# Altium schematic sheet Sheet1.SchDoc
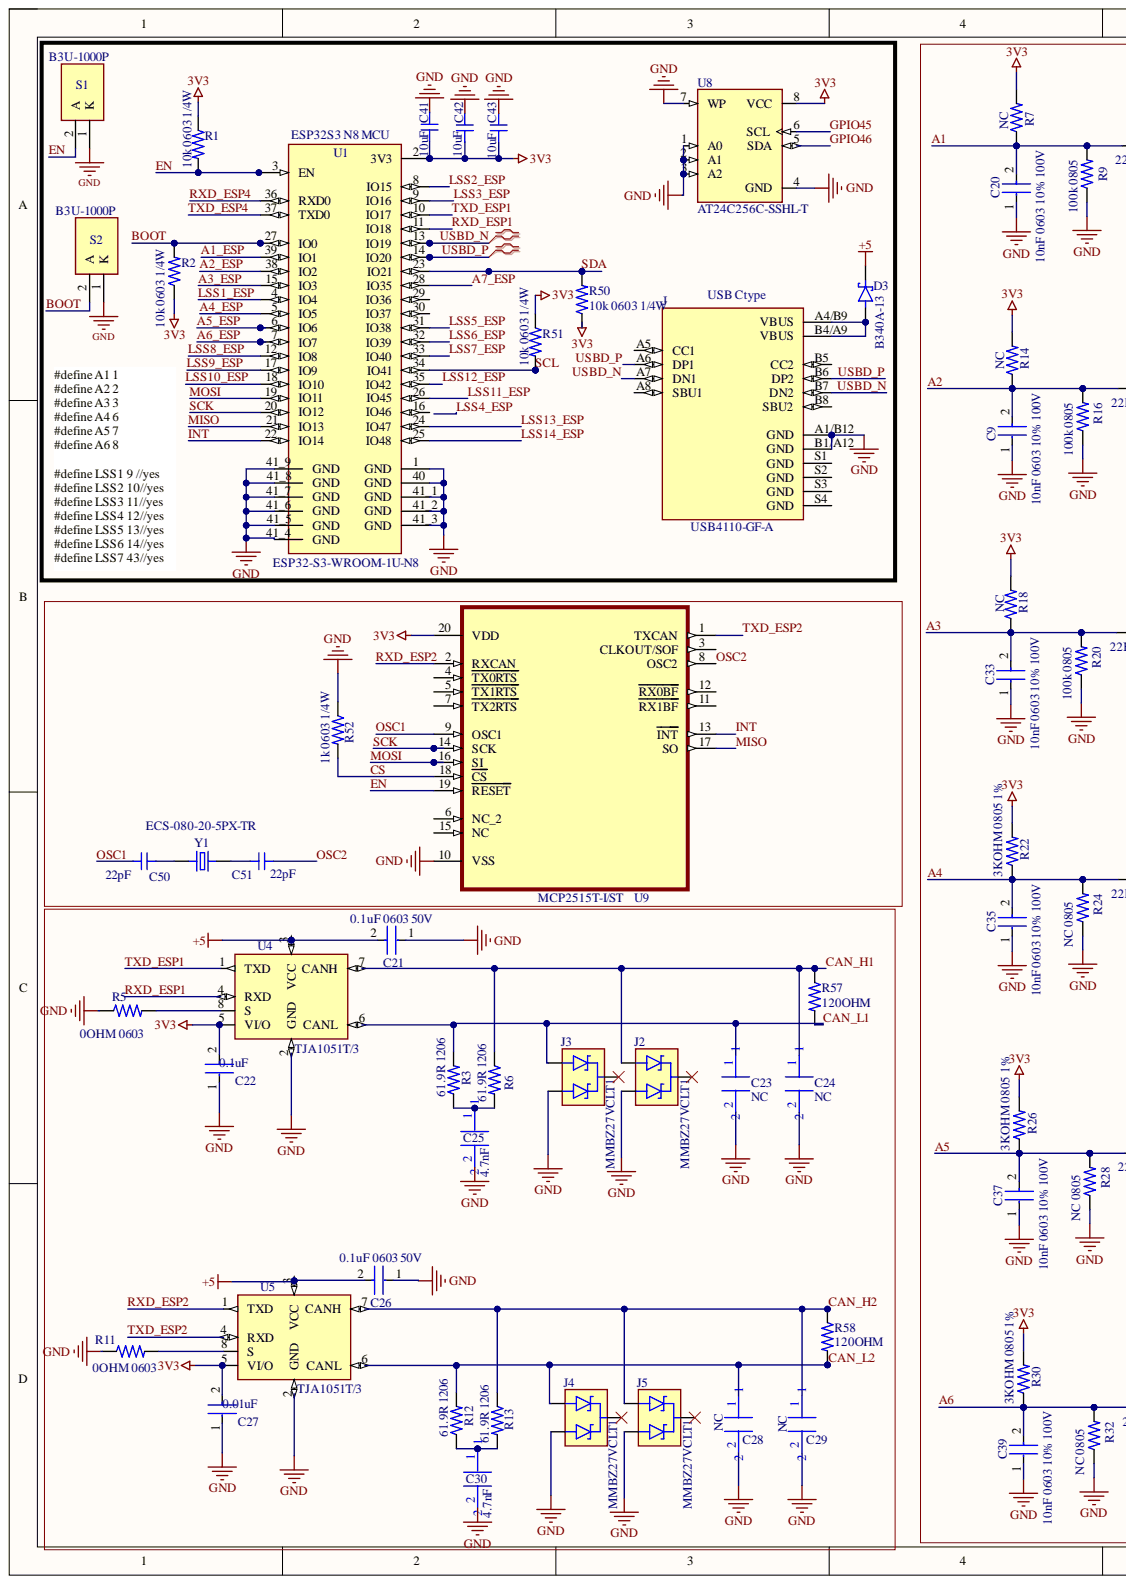
[diagram p0: Sheet1.SchDoc, left]
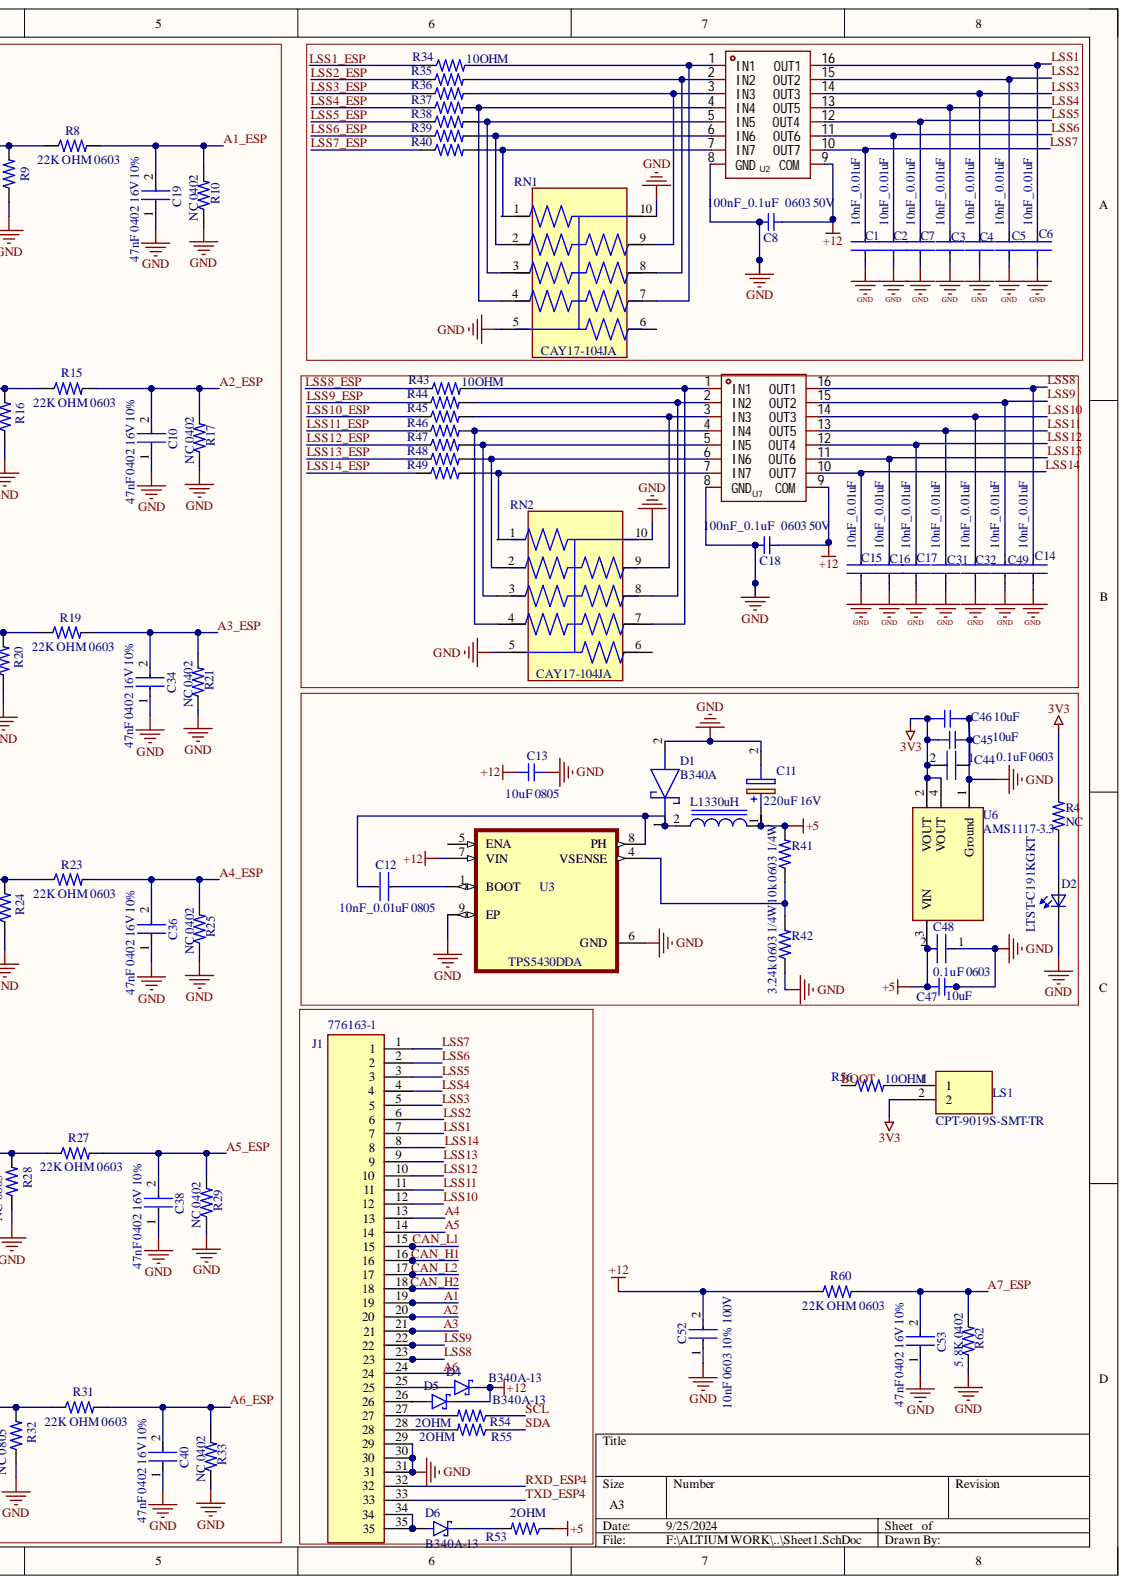
[diagram p1: Sheet1.SchDoc, right]

## Parts
C1  10nF_0.01uF  [0805]
C2  10nF_0.01uF  [0805]
C3  10nF_0.01uF  [0805]
C4  10nF_0.01uF  [0805]
C5  10nF_0.01uF  [0805]
C6  10nF_0.01uF  [0805]
C7  10nF_0.01uF  [0805]
C8  100nF_0.1uF   0603 50V  [Kemet_C0603_0]
C9  10nF 0603 10% 100V  [Kemet_C0603_0]
C10  47nF 0402 16V 10%  [CAPC0402X22N]
C11  220uF 16V  [CAPAE405X580N]
C12  10nF_0.01uF 0805  [0805]
C13  10uF 0805  [0805]
C14  10nF_0.01uF  [0805]
C15  10nF_0.01uF  [0805]
C16  10nF_0.01uF  [0805]
C17  10nF_0.01uF  [0805]
C18  100nF_0.1uF   0603 50V  [Kemet_C0603_0]
C19  47nF 0402 16V 10%  [CAPC0402X22N]
C20  10nF 0603 10% 100V  [Kemet_C0603_0]
C21  0.1uF 0603 50V  [Kemet_C0603_0]
C22  0.1uF  [Kemet_C0603_0]
C23  NC  [0805]
C24  NC  [0805]
C25  4.7nF  [0805]
C26  0.1uF 0603 50V  [Kemet_C0603_0]
C27  0.01uF  [Kemet_C0603_0]
C28  NC  [0805]
C29  NC  [0805]
C30  4.7nF  [0805]
C31  10nF_0.01uF  [0805]
C32  10nF_0.01uF  [0805]
C33  10nF 0603 10% 100V  [Kemet_C0603_0]
C34  47nF 0402 16V 10%  [CAPC0402X22N]
C35  10nF 0603 10% 100V  [Kemet_C0603_0]
C36  47nF 0402 16V 10%  [CAPC0402X22N]
C37  10nF 0603 10% 100V  [Kemet_C0603_0]
C38  47nF 0402 16V 10%  [CAPC0402X22N]
C39  10nF 0603 10% 100V  [Kemet_C0603_0]
C40  47nF 0402 16V 10%  [CAPC0402X22N]
C41  10uF  [0805]
C42  10uF  [0805]
C43  10uF  [0805]
C44  0.1uF 0603  [Kemet_C0603_0]
C45  10uF  [0805]
C46  10uF  [0805]
C47  10uF  [0805]
C48  0.1uF 0603  [Kemet_C0603_0]
C49  10nF_0.01uF  [0805]
C50  22pF  [Kemet_C0603_0]
C51  22pF  [Kemet_C0603_0]
C52  10nF 0603 10% 100V  [Kemet_C0603_0]
C53  47nF 0402 16V 10%  [CAPC0402X22N]
D1  B340A  [SOD3716X145N]
D2  LTST-C191KGKT  [0603]
D3  B340A-13  [DIOM5226X240N]
D4  B340A-13  [DIOM5226X240N]
D5  B340A-13  [DIOM5226X240N]
D6  B340A-13  [DIOM5226X240N]
J  USB4110-GF-A  [GCT_USB4105-GF-A]
J1  776163-1  [TE_776163-1]
J2  MMBZ27VCLT1  [SOT23-3]
J3  MMBZ27VCLT1  [SOT23-3]
J4  MMBZ27VCLT1  [SOT23-3]
J5  MMBZ27VCLT1  [SOT23-3]
L1  330uH  [INDPM5350X450N]
LS1  CPT-9019S-SMT-TR  [CPT9019SSMTTR]
R1  10k 0603 1/4W  [0603_R]
R2  10k 0603 1/4W  [0603_R]
R3  61.9R 1206  [R1206]
R4  NC  Package: 0603  [0603_R]
R5  0OHM 0603  Package: 0603  [0603_R]
R6  61.9R 1206  [R1206]
R7  NC  [R0805]
R8  22K OHM 0603  Package: 0603  [0603_R]
R9  100k 0805  [R0805]
R10  NC 0402  [R0402]
R11  0OHM 0603  Package: 0603  [0603_R]
R12  61.9R 1206  [R1206]
R13  61.9R 1206  [R1206]
R14  NC  [R0805]
R15  22K OHM 0603  Package: 0603  [0603_R]
R16  100k 0805  [R0805]
R17  NC 0402  [R0402]
R18  NC  [R0805]
R19  22K OHM 0603  Package: 0603  [0603_R]
R20  100k 0805  [R0805]
R21  NC 0402  [R0402]
R22  3KOHM 0805 1%  [R0805]
R23  22K OHM 0603  Package: 0603  [0603_R]
R24  NC 0805  [R0805]
R25  NC 0402  [R0402]
R26  3KOHM 0805 1%  [R0805]
R27  22K OHM 0603  Package: 0603  [0603_R]
R28  NC 0805  [R0805]
R29  NC 0402  [R0402]
R30  3KOHM 0805 1%  [R0805]
R31  22K OHM 0603  Package: 0603  [0603_R]
R32  NC 0805  [R0805]
R33  NC 0402  [R0402]
R34  10OHM  [R0402]
R35  10OHM  [R0402]
R36  10OHM  [R0402]
R37  10OHM  [R0402]  (value not drawn)
R38  10OHM  [R0402]  (value not drawn)
R39  10OHM  [R0402]  (value not drawn)
R40  10OHM  [R0402]  (value not drawn)
R41  10k 0603 1/4W  [0603_R]
R42  3.24k 0603 1/4W  [0603_R]
R43  10OHM  [R0402]
R44  10OHM  [R0402]
R45  10OHM  [R0402]
R46  10OHM  [R0402]  (value not drawn)
R47  10OHM  [R0402]  (value not drawn)
R48  10OHM  [R0402]  (value not drawn)
R49  10OHM  [R0402]  (value not drawn)
R50  10k 0603 1/4W  [0603_R]
R51  10k 0603 1/4W  [0603_R]
R52  1k 0603 1/4W  [0603_R]
R53  2OHM  [R1206]
R54  2OHM  [R1206]
R55  2OHM  [R1206]
R56  10OHM  [R0402]
R57  120OHM  [R1206]
R58  120OHM  [R1206]
R60  22K OHM 0603  Package: 0603  [0603_R]
R62  5.8K 0402  [R0402]
RN1  CAY17-104JA  [RESCAXS64P320X160X60-10N]
RN2  CAY17-104JA  [RESCAXS64P320X160X60-10N]
S1  B3U-1000P  [B3U-1000P]
S2  B3U-1000P  [B3U-1000P]
U1  ESP32-S3-WROOM-1U-N8  [XCVR_ESP32-S3-WROOM-1U]
U2  TPL7407LAQPWRQ1  [TSSOP-16_L5.0-W4.4-P0.65-LS6.4-BL]  (value not drawn)
U3  TPS5430DDA  [VREG_LM5017MR/NOPB]
U4  TJA1051T/3  [SOT96-1]
U5  TJA1051T/3  [SOT96-1]
U6  AMS1117-3.3  [Advanced_Monolithic_Systems_AMS1117_IPC_A]
U7  TPL7407LAQPWRQ1  [TSSOP-16_L5.0-W4.4-P0.65-LS6.4-BL]  (value not drawn)
U8  AT24C256C-SSHL-T  [SOIC127P600X175-8N - duplicate3]
U9  MCP2515T-I/ST  [SOP65P640X120-20N]
Y1  ECS-080-20-5PX-TR  [XTAL_ECS-080-20-5PX-TR]

## Nets
3V3: C22.2 C27.2 C41.1 C42.1 C43.1 C44.2 C45.2 C46.2 LS1.2 R1.1 R2.1 R4.2 R7.2 R14.2 R18.2 R22.2 R26.2 R30.2 R50.1 R51.1 U1.2(3V3) U4.5(VI/O) U5.5(VI/O) U6.2(VOUT) U6.4(VOUT) U8.8(VCC) U9.20(VDD)
+5: C11.1 C21.2 C26.2 C47.2 C48.2 D3.C L1.1 R41.2 R53.1 U4.3(VCC) U5.3(VCC) U6.3(VIN)
+12: C8.2 C13.2 C18.2 C52.2 D4.C D5.C R60.1 U2.9(COM) U3.7(VIN) U7.9(COM)
GND: C1.1 C2.1 C3.1 C4.1 C5.1 C6.1 C7.1 C8.1 C9.1 C10.1 C11.2 C13.1 C14.1 C15.1 C16.1 C17.1 C18.1 C19.1 C20.1 C21.1 C22.1 C23.2 C24.2 C25.2 C26.1 C27.1 C28.2 C29.2 C30.2 C31.1 C32.1 C33.1 C34.1 C35.1 C36.1 C37.1 C38.1 C39.1 C40.1 C41.2 C42.2 C43.2 C44.1 C45.1 C46.1 C47.1 C48.1 C49.1 C52.1 C53.1 D1.2 D2.1 J.A1/B12(GND) J.B1/A12(GND) J1.29 J1.30 J1.31 J2.2 J3.2 J4.2 J5.2 R5.1 R9.1 R10.1 R11.1 R16.1 R17.1 R20.1 R21.1 R24.1 R25.1 R28.1 R29.1 R32.1 R33.1 R42.1 R52.1 R62.1 RN1.5 RN1.10 RN2.5 RN2.10 S1.1 S2.1 U1.1(GND) U1.40(GND) U1.41_1(GND) U1.41_2(GND) U1.41_3(GND) U1.41_4(GND) U1.41_5(GND) U1.41_6(GND) U1.41_7(GND) U1.41_8(GND) U1.41_9(GND) U2.8(GND) U3.6(GND) U3.9(EP) U4.2(GND) U5.2(GND) U6.1(Ground) U7.8(GND) U8.1(A0) U8.2(A1) U8.3(A2) U8.4(GND) U8.7(WP) U9.10(VSS)
A1: C20.2 J1.19 R7.1 R8.1 R9.2
A1_ESP: C19.2 R8.2 R10.2 U1.39(IO1)
A2: C9.2 J1.20 R14.1 R15.1 R16.2
A2_ESP: C10.2 R15.2 R17.2 U1.38(IO2)
A3: C33.2 J1.21 R18.1 R19.1 R20.2
A3_ESP: C34.2 R19.2 R21.2 U1.15(IO3)
A4: C35.2 J1.13 R22.1 R23.1 R24.2
A4_ESP: C36.2 R23.2 R25.2 U1.5(IO5)
A5: C37.2 J1.14 R26.1 R27.1 R28.2
A5_ESP: C38.2 R27.2 R29.2 U1.6(IO6)
A6: C39.2 J1.24 R30.1 R31.1 R32.2
A6_ESP: C40.2 R31.2 R33.2 U1.7(IO7)
A7_ESP: C53.2 R60.2 R62.2 U1.28(IO35)
BOOT: R2.2 R56.1 S2.2 U1.27(IO0)
CAN_H1: C24.1 J1.16 J2.1 R6.1 R57.1 U4.7(CANH)
CAN_H2: C29.1 J1.18 J5.1 R13.1 R58.1 U5.7(CANH)
CAN_L1: C23.1 J1.15 J3.1 R3.2 R57.2 U4.6(CANL)
CAN_L2: C28.1 J1.17 J4.1 R12.2 R58.2 U5.6(CANL)
CS: R52.2 U9.18(CS)
EN: R1.2 S1.2 U1.3(EN) U9.19(RESET)
GPIO45: U8.6(SCL)
GPIO46: U8.5(SDA)
INT: U1.22(IO14) U9.13(INT)
LSS1: C6.2 J1.7 U2.16(OUT1)
LSS1_ESP: R34.1 U1.4(IO4)
LSS2: C5.2 J1.6 U2.15(OUT2)
LSS2_ESP: R35.1 U1.8(IO15)
LSS3: C4.2 J1.5 U2.14(OUT3)
LSS3_ESP: R36.1 U1.9(IO16)
LSS4: C3.2 J1.4 U2.13(OUT5)
LSS4_ESP: R37.1
LSS5: C7.2 J1.3 U2.12(OUT4)
LSS5_ESP: R38.1 U1.31(IO38)
LSS6: C2.2 J1.2 U2.11(OUT6)
LSS6_ESP: R39.1 U1.32(IO39)
LSS7: C1.2 J1.1 U2.10(OUT7)
LSS7_ESP: R40.1 U1.33(IO40)
LSS8: C14.2 J1.23 U7.16(OUT1)
LSS8_ESP: R43.1 U1.12(IO8)
LSS9: C49.2 J1.22 U7.15(OUT2)
LSS9_ESP: R44.1 U1.17(IO9)
LSS10: C32.2 J1.12 U7.14(OUT3)
LSS10_ESP: R45.1 U1.18(IO10)
LSS11: C31.2 J1.11 U7.13(OUT5)
LSS11_ESP: R46.1 U1.26(IO45)
LSS12: C17.2 J1.10 U7.12(OUT4)
LSS12_ESP: R47.1 U1.35(IO42)
LSS13: C16.2 J1.9 U7.11(OUT6)
LSS13_ESP: R48.1 U1.24(IO47)
LSS14: C15.2 J1.8 U7.10(OUT7)
LSS14_ESP: R49.1 U1.25(IO48)
MISO: U1.21(IO13) U9.17(SO)
MOSI: U1.19(IO11) U9.16(SI)
OSC1: C50.2 U9.9(OSC1)
OSC2: C51.1 U9.8(OSC2)
RXD_ESP1: U1.11(IO18) U4.4(RXD)
RXD_ESP2: U5.1(TXD) U9.2(RXCAN)
RXD_ESP4: J1.32 U1.36(RXD0)
SCK: U1.20(IO12) U9.14(SCK)
SCL: R51.2 R54.1 U1.34(IO41)
SDA: R50.2 R55.1 U1.23(IO21)
TXD_ESP1: U1.10(IO17) U4.1(TXD)
TXD_ESP2: U5.4(RXD) U9.1(TXCAN)
TXD_ESP4: J1.33 U1.37(TXD0)
USBD_N: J.A7(DN1) J.B7(DN2) U1.13(IO19)
USBD_P: J.A6(DP1) J.B6(DP2) U1.14(IO20)
NetC12_1: C12.1 D1.1 L1.2 U3.8(PH)
NetC12_2: C12.2 U3.1(BOOT)
NetC25_1: C25.1 R3.1 R6.2
NetC30_1: C30.1 R12.1 R13.2
NetC50_1: C50.1 Y1.1
NetC51_2: C51.2 Y1.2
NetD2_2: D2.2 R4.1
NetD3_A: D3.A J.A4/B9(VBUS) J.B4/A9(VBUS)
NetD4_A: D4.A J1.25
NetD5_A: D5.A J1.26
NetD6_A: D6.A J1.34 J1.35
NetD6_C: D6.C R53.2
NetJ1_27: J1.27 R54.2
NetJ1_28: J1.28 R55.2
NetLS1_1: LS1.1 R56.2
NetR5_2: R5.2 U4.8(S)
NetR11_2: R11.2 U5.8(S)
NetR34_2: R34.2 RN1.7 U2.1(IN1)
NetR35_2: R35.2 RN1.8 U2.2(IN2)
NetR36_2: R36.2 RN1.9 U2.3(IN3)
NetR37_2: R37.2 RN1.4 U2.4(IN4)
NetR38_2: R38.2 RN1.3 U2.5(IN5)
NetR39_2: R39.2 RN1.2 U2.6(IN6)
NetR40_2: R40.2 RN1.1 U2.7(IN7)
NetR41_1: R41.1 R42.2 U3.4(VSENSE)
NetR43_2: R43.2 RN2.7 U7.1(IN1)
NetR44_2: R44.2 RN2.8 U7.2(IN2)
NetR45_2: R45.2 RN2.9 U7.3(IN3)
NetR46_2: R46.2 RN2.4 U7.4(IN4)
NetR47_2: R47.2 RN2.3 U7.5(IN5)
NetR48_2: R48.2 RN2.2 U7.6(IN6)
NetR49_2: R49.2 RN2.1 U7.7(IN7)

Not connected: J A5(CC1) A8(SBU1) B5(CC2) B8(SBU2) S1(GND) S2(GND) S3(GND) S4(GND); J2 3; J3 3; J4 3; J5 3; RN1 6; RN2 6; U1 16(IO46) 29(IO36) 30(IO37); U3 5(ENA); U9 3(CLKOUT/SOF) 4(TX0RTS) 5(TX1RTS) 6(NC_2) 7(TX2RTS) 11(RX1BF) 12(RX0BF) 15(NC)

## Library data of the parts
C8 C18 = 100nF_0.1uF: Component Kind: Standard; Description: 100nF_0.1uF; Library Name: Capacitors.SchLib
C9 C10 C19 C20 C33-C40 C52 C53 = C0603C103K9RACTU: 10nF SMD Multilayer Ceramic Capacitor, 10000 pF, 6.3 V, 0603 [1608 Metric], ± 10%, X7R, C Series KEMET; Component Kind: Standard; Library Name: Capacitors.SchLib
C11 = B41856C6227M000: Capacitor Polarised; Manufacturer_Name: TDK; Component Kind: Standard; Datasheet Link: https://componentsearchengine.com//B41856C6227M000.pdf; Height: 17mm; Library Name: Capacitors.SchLib; Manufacturer_Part_Number: B41856C6227M000; Mouser2 Part Number: 871-B41856C6227M000; Mouser2 Price/Stock: https://www.mouser.com/Search/Refine.aspx?Keyword=871-B41856C6227M000
C12 = 10nF_0.01uF: Component Kind: Standard; Description: 10nF_0.01uF; Library Name: Capacitors.SchLib
C13 C41-C43 C45-C47 = 10uF_E14: 10uF Element 14; Component Kind: Standard; Library Name: Capacitors.SchLib
C21 C22 C26 C27 C44 C48 = C0603C104K5RACTU_1: 0.1uF X7R 10% Multilayer Ceramic Capacitors (MLCC) - SMD/SMT 50volts 0.1uF X7R 10%; Component Kind: Standard; Library Name: Capacitors.SchLib
C23 C24 C28 C29 = 100pF: Component Kind: Standard; Description: 100pF; Library Name: Capacitors.SchLib
D1 = 1N5819HWQ-7-F: Schottky Diode; Manufacturer_Name: Diodes Inc.; Component Kind: Standard; Datasheet Link: https://componentsearchengine.com//1N5819HWQ-7-F.pdf; Height: 1.45mm; Library Name: Diodes.SchLib; Manufacturer_Part_Number: 1N5819HWQ-7-F; Mouser2 Part Number: 621-1N5819HWQ-7-F; Mouser2 Price/Stock: https://www.mouser.com/Search/Refine.aspx?Keyword=621-1N5819HWQ-7-F
D2 = LTST-C191KGKT: Green 571nm LED Indication - Discrete 2V 0603 _1608 Metric_; MF: Lite-On Inc.; Availability: Unavailable; Component Kind: Standard; Library Name: LED.SchLib; MP: LTST-C191KGKT; Package: 0603 Lite-On; Price: None; SnapEDA_Link: https://www.snapeda.com/parts/LTST-C191KGKT/Lite-On%20Inc./view-part/48565/?ref=snap
D3-D6 = B340A-13: Diode Schottky 40 V 3A Surface Mount SMA; MF: Diodes Inc.; Availability: In Stock; Check_prices: https://www.snapeda.com/parts/B340A-13/Diodes+Inc./view-part/?ref=eda; Component Kind: Standard; Library Name: Diodes.SchLib; MP: B340A-13; Package: DO-214 Diodes Inc.; Price: None; Purchase-URL: https://pricing.snapeda.com/search/part/B340A-13/?ref=eda; SnapEDA_Link: https://www.snapeda.com/parts/B340A-13/Diodes+Inc./view-part/?ref=snap
J = USB4110-GF-A: USB-C _USB TYPE-C_ USB 2.0 Receptacle Connector 24 _16+8 Dummy_ Position Surface Mount, Right Angle; Through Hole; MF: GCT; Component Kind: Standard; Digi-Key_Part_Number: 2073-USB4110-GF-A-1-ND; Digi-Key_Purchase_URL: https://www.digikey.com/product-detail/en/gct/USB4110-GF-A/2073-USB4110-GF-A-1-ND/10384548?utm_source=snapeda&utm_medium=aggregator&utm_campaign=symbol; Library Name: Connectors.SchLib; MP: USB4110-GF-A; Package: None; SnapEDA_Link: https://www.snapeda.com/parts/USB4110-GF-A/Global%20Connector%20Technology/view-part/4001167/?ref=snap
J1 = 776163-1: Rectangular Power Connector, AMPSEAL Series, Through Hole, Plug, 35, 4 mm, Pin; MF: TE Connectivity; Component Kind: Standard; Digi-Key_Part_Number: A106460-ND; Digi-Key_Purchase_URL: https://www.digikey.com/product-detail/en/te-connectivity-amp-connectors/776163-1/A106460-ND/2327364?utm_source=snapeda&utm_medium=aggregator&utm_campaign=symbol; EU_RoHS_Compliance: Compliant; Library Name: Connectors.SchLib; MP: 776163-1; Package: None; SnapEDA_Link: https://www.snapeda.com/parts/776163-1/TE%20Connectivity%20AMP%20Connectors/view-part/546665/?ref=snap; TE_purchase_URL: https://www.te.com/usa-en/product-776163-1.html?te_bu=Cor&te_type=disp&te_campaign=seda_glo_cor-seda-global-disp-prtnr-fy19-seda-model-bom-cta_sma-317_1&elqCampaignId=32493
J2-J5 = MMBZ27VCLT1: 38V Clamp 1A Ipp Tvs Diode Surface Mount SOT-23-3 (TO-236); MF: ON Semiconductor; Check_prices: https://www.snapeda.com/parts/MMBZ27VCLT1/Onsemi/view-part/?ref=eda; Component Kind: Standard; Digi-Key_Part_Number: MMBZ27VCLT1OSTR-ND; Library Name: Transistor.SchLib; MP: MMBZ27VCLT1; Package: SOT-23-3 ON Semiconductor; Purchase-URL: https://pricing.snapeda.com/search/part/MMBZ27VCLT1/?ref=eda; SnapEDA_Link: https://www.snapeda.com/parts/MMBZ27VCLT1/Onsemi/view-part/?ref=snap
L1 = 10uH: MF: Bourns; MP: SRR1260-470M; Availability: Unavailable; Component Kind: Standard; Description: 10uH; Library Name: Inductors.SchLib; Package: Nonstandard Bourns; Price: None; SnapEDA_Link: https://www.snapeda.com/parts/SRR1260-470M/Bourns/view-part/283129/?ref=snap
LS1 = CPT-9019S-SMT-TR: Loudspeaker or Buzzer; Manufacturer_Name: CUI Devices; Component Kind: Standard; Datasheet Link: https://componentsearchengine.com//CPT-9019S-SMT-TR.pdf; Height: 1.9mm; Library Name: BUZZER.SchLib; Manufacturer_Part_Number: CPT-9019S-SMT-TR; Mouser2 Part Number: 490-CPT-9019S-SMT-TR; Mouser2 Price/Stock: https://www.mouser.com/Search/Refine.aspx?Keyword=490-CPT-9019S-SMT-TR
R1 R2 R41 R42 R50 R51 = MCWR06X1002FTL: SMD Chip Resistor, 10 kohm, ± 1%, 100 mW, 0603 [1608 Metric], Thick Film, General Purpose; Manufacturer_Name: Susumu; Manufacturer_Part_Number: RGA2012P-102-B-T1; Component Kind: Standard; Datasheet Link: https://componentsearchengine.com/Datasheets/1/RGA2012P-102-B-T1.pdf; Height: 0.55mm; Library Name: Resistors.SchLib; Mouser2 Part Number: 754-RGA2012P-102BT1; Mouser2 Price/Stock: https://www.mouser.com/Search/Refine.aspx?Keyword=754-RGA2012P-102BT1
R3 R6 R12 R13 = 510: 510 SMD; Manufacturer_Name: Susumu; Manufacturer_Part_Number: RGA2012P-102-B-T1; Component Kind: Standard; Datasheet Link: https://componentsearchengine.com/Datasheets/1/RGA2012P-102-B-T1.pdf; Height: 0.55mm; Library Name: Resistors.SchLib; Mouser2 Part Number: 754-RGA2012P-102BT1; Mouser2 Price/Stock: https://www.mouser.com/Search/Refine.aspx?Keyword=754-RGA2012P-102BT1
R4 R5 R11 R53-R55 R57 R58 = 0OHM__E14: SMD Chip Resistor, 0 ohm, ± 5%, 100 mW, 0603 [1608 Metric], Thick Film, General Purpose; MF: TE Connectivity; MP: 1879746-7; Power_Rating: .1 W; Resistance: 6.81K; Tolerance: .1%; Voltage_Rating: 75 V; Availability: Unavailable; Component Kind: Standard; Library Name: Resistors.SchLib; Price: None; SnapEDA_Link: https://www.snapeda.com/parts/1879746-7/TE%20Connectivity/view-part/2212977/?ref=snap
  R53-R55 R57 R58: Package: 0603
R7 R14 R18 R22 R26 R30 = 3.3K E14: 3KOHM; MF: TE Connectivity; MP: 1879746-7; Power_Rating: .1 W; Resistance: 6.81K; Tolerance: .1%; Voltage_Rating: 75 V; Availability: Unavailable; Component Kind: Standard; Library Name: Resistors.SchLib; Package: 0603; Price: None; SnapEDA_Link: https://www.snapeda.com/parts/1879746-7/TE%20Connectivity/view-part/2212977/?ref=snap
R8 R15 R19 R23 R27 R31 R60 = 22.1K OHM_1: MF: TE Connectivity; MP: 1879746-7; Power_Rating: .1 W; Resistance: 6.81K; Tolerance: .1%; Voltage_Rating: 75 V; Availability: Unavailable; Component Kind: Standard; Description: 22.1K OHM_1; Library Name: Resistors.SchLib; Price: None; SnapEDA_Link: https://www.snapeda.com/parts/1879746-7/TE%20Connectivity/view-part/2212977/?ref=snap
R9 R10 R16 R17 R20 R21 R24 R25 R28 R29 R32 R33 R62 = 100K OHM: MCMR06X1003FTL; MF: TE Connectivity; MP: 1879746-7; Power_Rating: .1 W; Resistance: 6.81K; Tolerance: .1%; Voltage_Rating: 75 V; Availability: Unavailable; Component Kind: Standard; Library Name: Resistors.SchLib; Package: 0603; Price: None; SnapEDA_Link: https://www.snapeda.com/parts/1879746-7/TE%20Connectivity/view-part/2212977/?ref=snap
R34-R40 R43-R49 R56 = 270OHM__E14: 10ohm 0402; MF: TE Connectivity; MP: 1879746-7; Power_Rating: .1 W; Resistance: 6.81K; Tolerance: .1%; Voltage_Rating: 75 V; Availability: Unavailable; Component Kind: Standard; Library Name: Resistors.SchLib; Package: 0603; Price: None; SnapEDA_Link: https://www.snapeda.com/parts/1879746-7/TE%20Connectivity/view-part/2212977/?ref=snap
R52 = MCWR06X1002FTL: SMD Chip Resistor, 1 kohm, ± 1%, 100 mW, 0603 [1608 Metric], Thick Film, General Purpose; Manufacturer_Name: Susumu; Manufacturer_Part_Number: RGA2012P-102-B-T1; Component Kind: Standard; Datasheet Link: https://componentsearchengine.com/Datasheets/1/RGA2012P-102-B-T1.pdf; Height: 0.55mm; Library Name: Resistors.SchLib; Mouser2 Part Number: 754-RGA2012P-102BT1; Mouser2 Price/Stock: https://www.mouser.com/Search/Refine.aspx?Keyword=754-RGA2012P-102BT1
RN1 RN2 = CAY17-104JA: Res Thick Film Array 100K Ohm 5% 0.25W(1/4W) Â±250ppm/Â°C BUS 10-Pin 1206(8 X 0603) Convex SMD T/R; MF: Bourns; Availability: In Stock; Check_prices: https://www.snapeda.com/parts/CAY17-104JA/Bourns/view-part/?ref=eda; Component Kind: Standard; Library Name: Resistors.SchLib; MP: CAY17-104JA; Package: None; Price: None; Purchase-URL: https://pricing.snapeda.com/search/part/CAY17-104JA/?ref=eda; SnapEDA_Link: https://www.snapeda.com/parts/CAY17-104JA/Bourns/view-part/?ref=snap
S1 S2 = B3U-1000P: Switch; Manufacturer_Name: Omron Electronics; Component Kind: Standard; Datasheet Link: https://componentsearchengine.com//B3U-1000P.pdf; Library Name: Buttons.SchLib; Manufacturer_Part_Number: B3U-1000P; Mouser2 Part Number: 653-B3U-1000P; Mouser2 Price/Stock: https://www.mouser.com/Search/Refine.aspx?Keyword=653-B3U-1000P
U1 = ESP32-S3-WROOM-1U: 2.4 GHz Wi-Fi (802.11 b/g/n) and Bluetooth 5 (LE) module; MF: Espressif Systems; Availability: Not in stock; Check_prices: https://www.snapeda.com/parts/ESP32-S3-WROOM-1U/Espressif+Systems/view-part/?ref=eda; Component Kind: Standard; Library Name: ESP.SchLib; MP: ESP32-S3-WROOM-1U; Package: Package; Price: None; SnapEDA_Link: https://www.snapeda.com/parts/ESP32-S3-WROOM-1U/Espressif+Systems/view-part/?ref=snap
U2 U7 = TPL7407LAQPWRQ1: Manufacturer: TI(德州仪器); Add into BOM: yes; Component Kind: Standard; Convert to PCB: yes; Datasheet: https://www.ti.com/cn/lit/gpn/tpl7407la-q1; Device: TPL7407LAQPWRQ1; JLCPCB Part Class: Extended Part; LCSC Part Name: TPL7407LAQPWRQ1; Library Name: ICS.SchLib; Manufacturer Part: TPL7407LAQPWRQ1; Supplier: LCSC; Supplier Footprint: TSSOP-16; Supplier Part: C2149969; Symbol: TPL7407LAQPWRQ1
U3 = TPS5430DDA: 5.5Vè‡³36Vè¾“å…¥ï¼Œ3Aï¼Œ500kHzé™åŽ‹è½¬æ¢å™¨; MF: Texas Instruments; Availability: Unavailable; Component Kind: Standard; DigiKey-Purchase-URL: https://snapeda.com/shop?store=DigiKey&id=226174; Mouser-Purchase-URL: https://snapeda.com/shop?store=Mouser&id=226174; MP: TPS5430DDA; Package: HSOIC-8 Texas Instruments; Price: None; SnapEDA_Link: https://www.snapeda.com/parts/TPS5430DDA/Texas%20Instruments/view-part/226174/?ref=snap; Texas_Instruments-Purchase-URL: https://snapeda.com/shop?store=Texas+Instruments&id=226174; Library Name: IC_Advanced_Monolithic_Systems_AMS1117-3.3_eec.SchLib
U4 U5 = TJA1051T/3: 1/1 ÐŸÑ€Ð¸ÐµÐ¼Ð¾Ð¿ÐµÑ€ÐµÐ´Ð°Ñ‚Ñ‡Ð¸Ðº ÐŸÐ¾Ð»Ð¾Ð²Ð¸Ð½Ð° CANbus 8-SO; MF: NXP USA; Availability: In Stock; Check_prices: https://www.snapeda.com/parts/TJA1051T/3/NXP+USA+Inc./view-part/?ref=eda; Component Kind: Standard; Library Name: ICS.SchLib; MP: TJA1051T/3; Package: SO-8 NXP Semiconductors; Price: None; Purchase-URL: https://pricing.snapeda.com/search/part/TJA1051T/3/?ref=eda; SnapEDA_Link: https://www.snapeda.com/parts/TJA1051T/3/NXP+USA+Inc./view-part/?ref=snap
U8 = AT24C256C-SSHL-T: EEPROM Memory IC 256Kb _32K x 8_ IÂ²C 1MHz 550ns 8-SOIC; MF: Microchip; Component Kind: Standard; Digi-Key_Part_Number: AT24C256C-SSHL-TCT-ND; Digi-Key_Purchase_URL: https://www.digikey.com/product-detail/en/microchip-technology/AT24C256C-SSHL-T/AT24C256C-SSHL-TCT-ND/3178468?utm_source=snapeda&utm_medium=aggregator&utm_campaign=symbol; Library Name: ICS.SchLib; MP: AT24C256C-SSHL-T; Package: SO-8 Microchip; SnapEDA_Link: https://www.snapeda.com/parts/AT24C256C-SSHL-T/Microchip/view-part/1864067/?ref=snap
U9 = MCP2515T-I/ST: CANbus Controller CAN 2.0 SPI Interface 20-TSSOP; MF: Microchip; Component Kind: Standard; Digi-Key_Part_Number: MCP2515T-I/STCT-ND; Digi-Key_Purchase_URL: https://www.digikey.com/product-detail/en/microchip-technology/MCP2515T-I-ST/MCP2515T-I-STCT-ND/4307903?utm_source=snapeda&utm_medium=aggregator&utm_campaign=symbol; Library Name: ICS.SchLib; MP: MCP2515T-I/ST; Package: TSSOP-20 Microchip; SnapEDA_Link: https://www.snapeda.com/parts/MCP2515T-I/ST/Microchip/view-part/39885/?ref=snap
Y1 = ECS-080-20-5PX-TR: 8 MHz Â±30ppm Crystal 20pF 60 Ohms HC-49/US; MF: ECS; Availability: In Stock; Check_prices: https://www.snapeda.com/parts/ECS-080-20-5PX-TR/ECS+Inc./view-part/?ref=eda; Component Kind: Standard; Library Name: Oscillator.SchLib; MP: ECS-080-20-5PX-TR; Package: HC49/US ECS International; Price: None; Purchase-URL: https://pricing.snapeda.com/search/part/ECS-080-20-5PX-TR/?ref=eda; SnapEDA_Link: https://www.snapeda.com/parts/ECS-080-20-5PX-TR/ECS+Inc./view-part/?ref=snap
C1-C7 C14-C17 C31 C32 C49 = 10nF_0.01uF: Component Kind: Standard; Description: 10nF_0.01uF; Library Name: Capacitors.SchLib
C25 C30 = 4.7nF: Component Kind: Standard; Library Name: Capacitors.SchLib
C50 C51 = 22pF: Component Kind: Standard; Description: 22pF; Library Name: Capacitors.SchLib
U6 = AMS1117-3.3: Component Kind: Standard; Library Name: IC_Advanced_Monolithic_Systems_AMS1117-3.3_eec.SchLib
